# Altium schematic sheet 16-DPLL.SchDoc(16-DPLL)
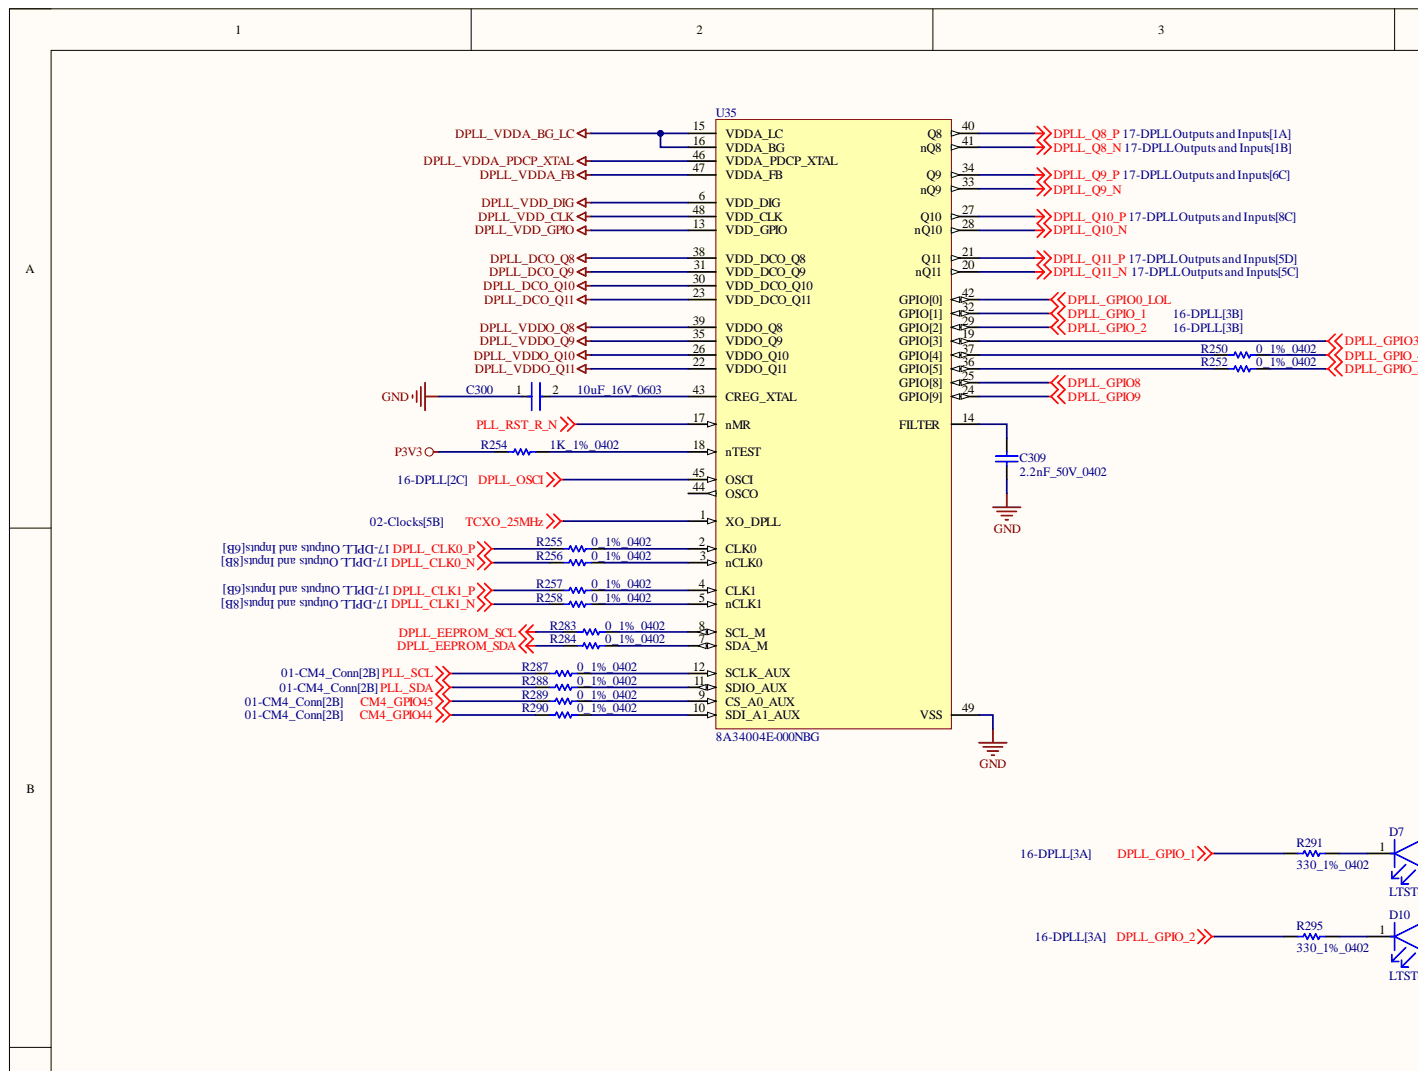
[diagram p0: 16-DPLL.SchDoc, top left]
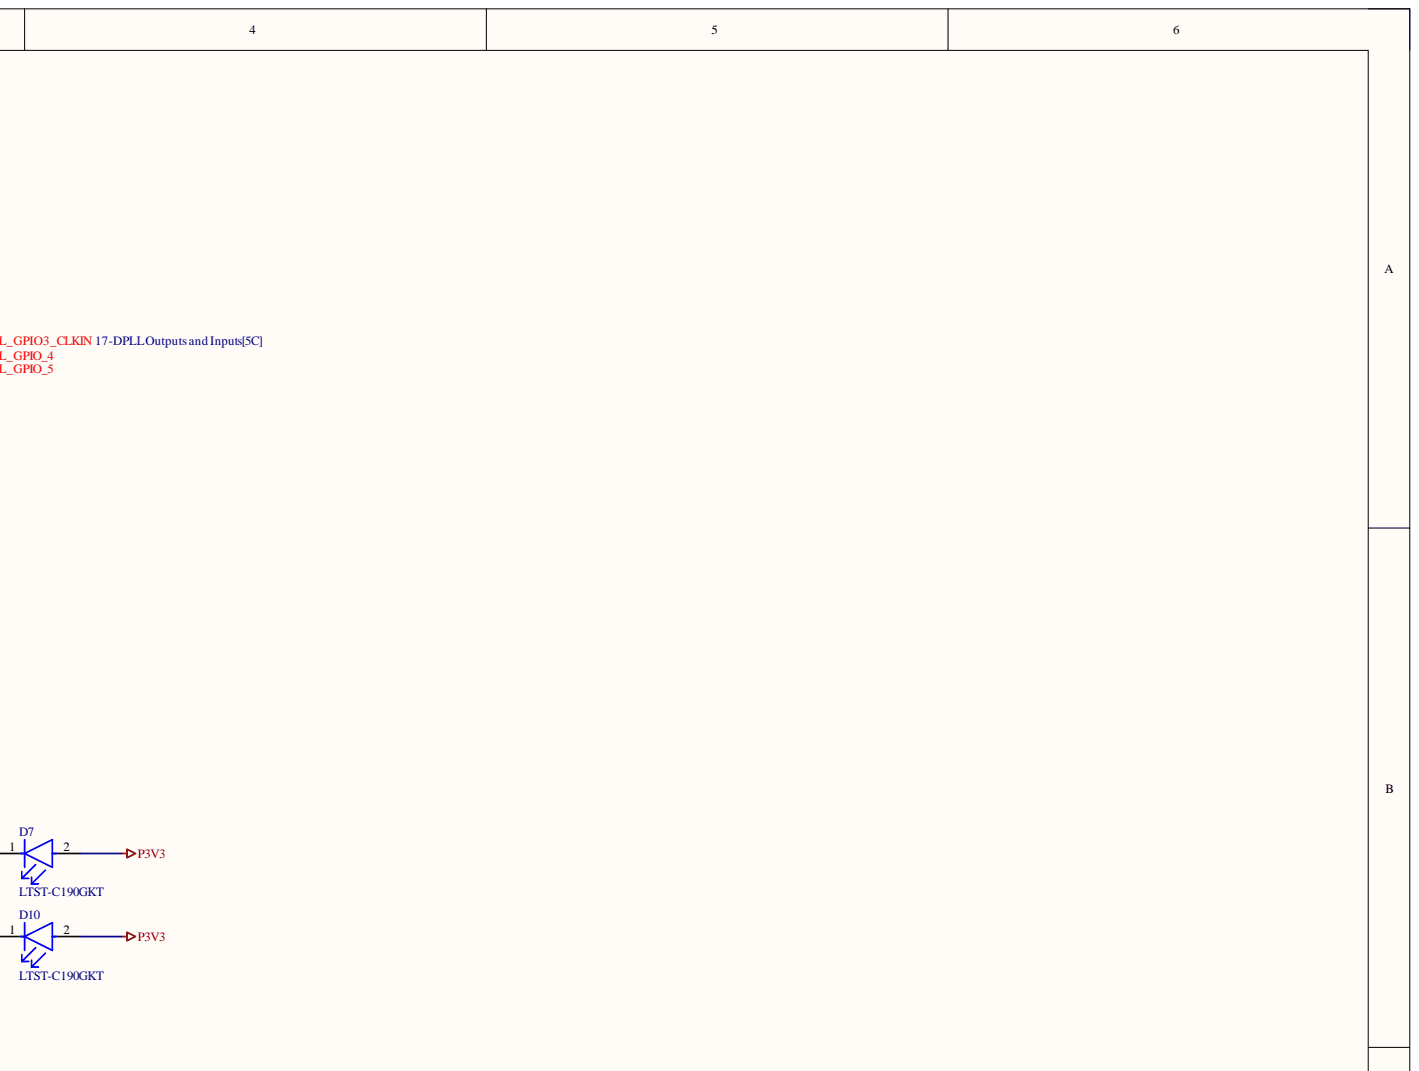
[diagram p1: 16-DPLL.SchDoc, top right]
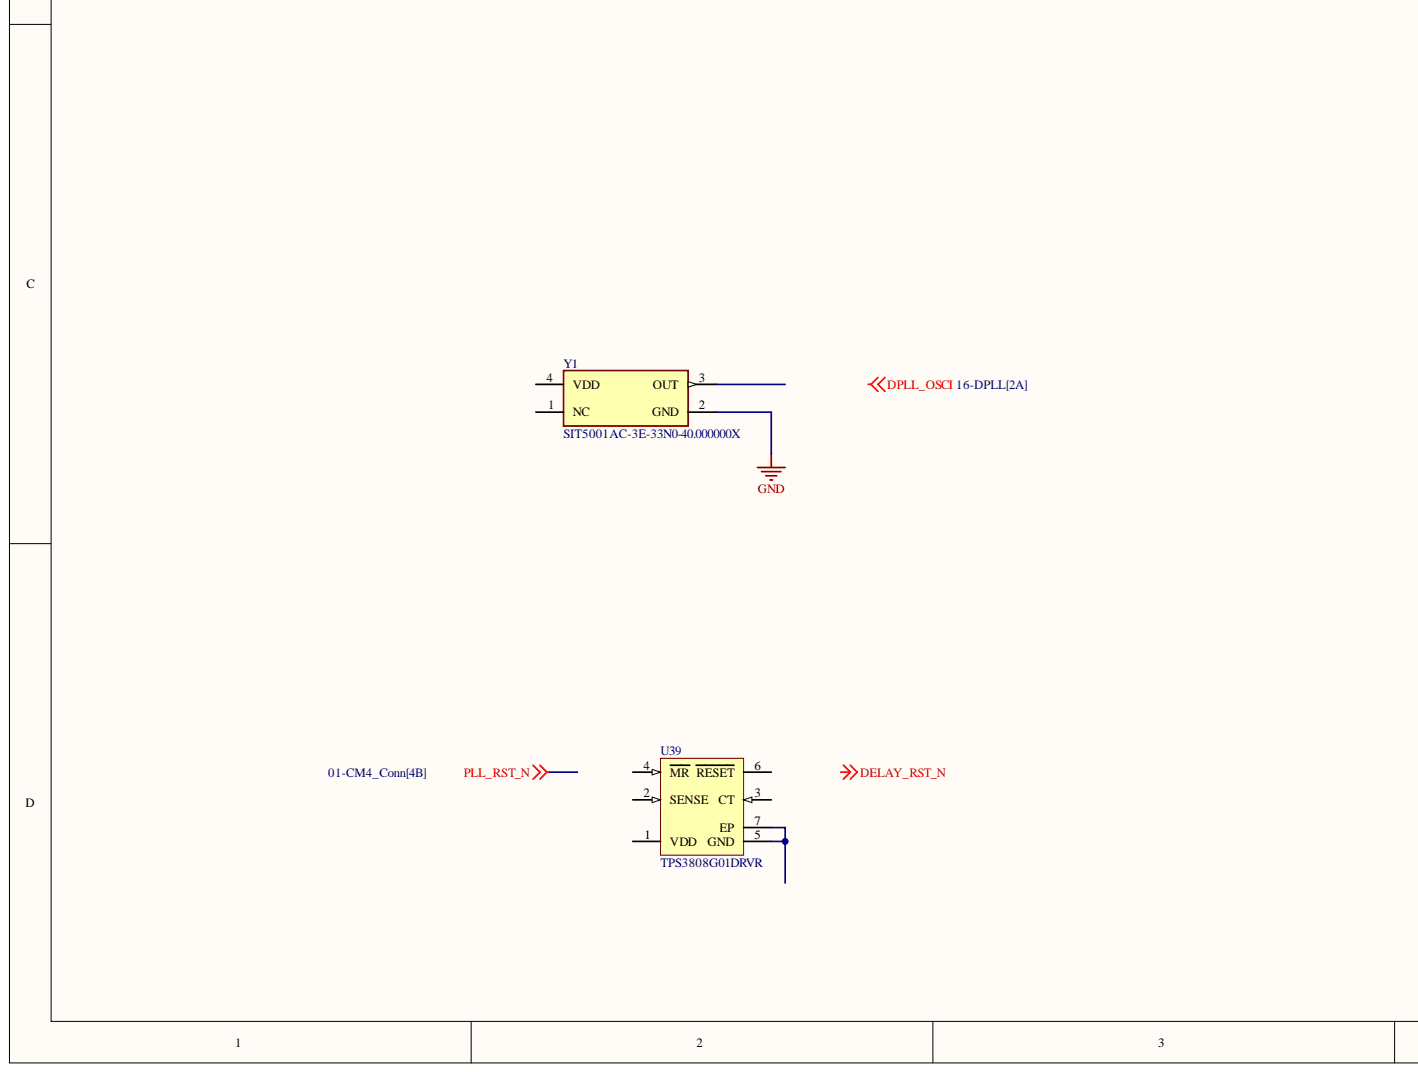
[diagram p2: 16-DPLL.SchDoc, bottom left]
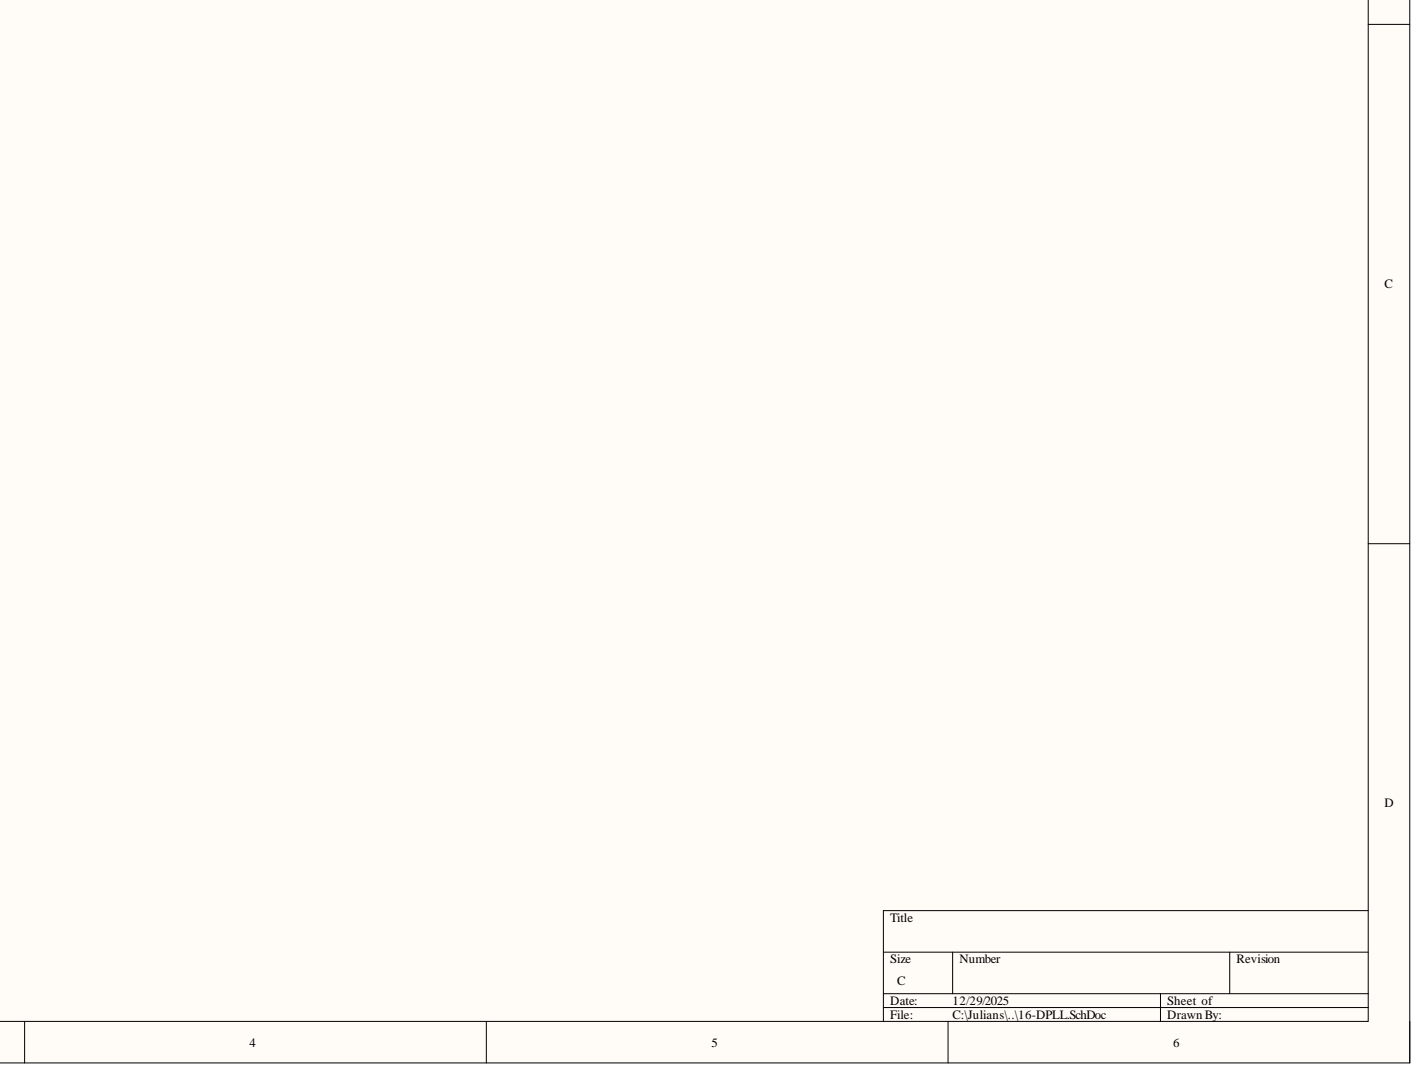
[diagram p3: 16-DPLL.SchDoc, bottom right]

## Parts
C300  10uF_16V_0603  [FP-CC0603-DC-MFG]
C309  2.2nF_50V_0402  [CAPC1005X60X26LL10T16]
D7  LTST-C190GKT  [FP-LTST-C190GKT-MFG]
D10  LTST-C190GKT  [FP-LTST-C190GKT-MFG]
R250  0_1%_0402  [RESC1005X40X25LL05T05]
R252  0_1%_0402  [RESC1005X40X25LL05T05]
R254  1K_1%_0402  [RESC1005X40X25ML05T10]
R255  0_1%_0402  [RESC1005X40X25LL05T05]
R256  0_1%_0402  [RESC1005X40X25LL05T05]
R257  0_1%_0402  [RESC1005X40X25LL05T05]
R258  0_1%_0402  [RESC1005X40X25LL05T05]
R283  0_1%_0402  [RESC1005X40X25LL05T05]
R284  0_1%_0402  [RESC1005X40X25LL05T05]
R287  0_1%_0402  [RESC1005X40X25LL05T05]
R288  0_1%_0402  [RESC1005X40X25LL05T05]
R289  0_1%_0402  [RESC1005X40X25LL05T05]
R290  0_1%_0402  [RESC1005X40X25LL05T05]
R291  330_1%_0402  [RESC1005X40X25NL10T10]
R295  330_1%_0402  [RESC1005X40X25NL10T10]
U35  8A34004E-000NBG  [NBG48P1_MFG]
U39  TPS3808G01DRVR  [DRV0006A_V]
Y1  SIT5001AC-3E-33N0-40.000000X  [FP-SiT5001-3-MFG]

## Nets
CM4_GPIO44: R290.1
CM4_GPIO45: R289.1
DPLL_CLK0_N: R256.1
DPLL_CLK0_P: R255.1
DPLL_CLK1_N: R258.1
DPLL_CLK1_P: R257.1
DPLL_DCO_Q8: U35.38(VDD_DCO_Q8)
DPLL_DCO_Q9: U35.31(VDD_DCO_Q9)
DPLL_DCO_Q10: U35.30(VDD_DCO_Q10)
DPLL_DCO_Q11: U35.23(VDD_DCO_Q11)
DPLL_EEPROM_SCL: R283.1
DPLL_EEPROM_SDA: R284.1
DPLL_GPIO0_LOL: U35.42(GPIO[0])
DPLL_GPIO3_CLKIN: U35.19(GPIO[3])
DPLL_GPIO8: U35.25(GPIO[8])
DPLL_GPIO9: U35.24(GPIO[9])
DPLL_GPIO_1: R291.1 U35.32(GPIO[1])
DPLL_GPIO_2: R295.1 U35.29(GPIO[2])
DPLL_GPIO_4: R250.2
DPLL_GPIO_5: R252.2
DPLL_OSCI: U35.45(OSCI)
DPLL_Q8_N: U35.41(nQ8)
DPLL_Q8_P: U35.40(Q8)
DPLL_Q9_N: U35.33(nQ9)
DPLL_Q9_P: U35.34(Q9)
DPLL_Q10_N: U35.28(nQ10)
DPLL_Q10_P: U35.27(Q10)
DPLL_Q11_N: U35.20(nQ11)
DPLL_Q11_P: U35.21(Q11)
DPLL_VDD_CLK: U35.48(VDD_CLK)
DPLL_VDD_DIG: U35.6(VDD_DIG)
DPLL_VDD_GPIO: U35.13(VDD_GPIO)
DPLL_VDDA_BG_LC: U35.15(VDDA_LC) U35.16(VDDA_BG)
DPLL_VDDA_FB: U35.47(VDDA_FB)
DPLL_VDDA_PDCP_XTAL: U35.46(VDDA_PDCP_XTAL)
DPLL_VDDO_Q8: U35.39(VDDO_Q8)
DPLL_VDDO_Q9: U35.35(VDDO_Q9)
DPLL_VDDO_Q10: U35.26(VDDO_Q10)
DPLL_VDDO_Q11: U35.22(VDDO_Q11)
GND: C300.1 C309.1 U35.49(VSS) Y1.2(GND)
P3V3: D7.2 D10.2 R254.2
PLL_RST_R_N: U35.17(nMR)
PLL_SCL: R287.1
PLL_SDA: R288.1
TCXO_25MHz: U35.1(XO_DPLL)
NetC300_2: C300.2 U35.43(CREG_XTAL)
NetC309_2: C309.2 U35.14(FILTER)
NetD7_1: D7.1 R291.2
NetD10_1: D10.1 R295.2
NetR250_1: R250.1 U35.37(GPIO[4])
NetR252_1: R252.1 U35.36(GPIO[5])
NetR254_1: R254.1 U35.18(nTEST)
NetR255_2: R255.2 U35.2(CLK0)
NetR256_2: R256.2 U35.3(nCLK0)
NetR257_2: R257.2 U35.4(CLK1)
NetR258_2: R258.2 U35.5(nCLK1)
NetR283_2: R283.2 U35.8(SCL_M)
NetR284_2: R284.2 U35.7(SDA_M)
NetR287_2: R287.2 U35.12(SCLK_AUX)
NetR288_2: R288.2 U35.11(SDIO_AUX)
NetR289_2: R289.2 U35.9(CS_A0_AUX)
NetR290_2: R290.2 U35.10(SDI_A1_AUX)
NetU39_5: U39.5(GND) U39.7(EP)
DELAY_RST_N:
PLL_RST_N:

Not connected: U35 44(OSCO); U39 1(VDD) 2(SENSE) 3(CT) 4(MR) 6(RESET); Y1 1(NC) 3(OUT) 4(VDD)

## Library data of the parts
C300 = CMP-00621-81932632-1: CC0603KRX5R7BB106 Multilayer Ceramic Capacitor, 10 uF, 16 V, ± 10%, X5R, 0603 [1608 Metric]; Capacitance: 10µF; Voltage Rating: 16V; Case/Package: 0603; Component Kind: Standard; Dielectric: X5R; Halogen Free: Halogen Free; Height: 1mm; Lead Free: Lead Free; Library Name: Altium Content Vault; Material: Ceramic; Max Operating Temperature: 85°C; Min Operating Temperature: -55°C; NexarPartId: 81932632; RoHS: Non-Compliant; Tolerance: 10%; Voltage Rating (DC): 16V
C309 = CMP-2008-01093-1: Manufacturer: Vitramon; Part Number: VJ0402Y222KXAAP; Component Kind: Standard; Library Name: Altium Content Vault
D7 D10 = CMP-22017-000005-2: LED GREEN CLEAR CHIP SMD; Dominant Wavelength: 569nm; Forward Current: 30mA; Forward Voltage: 2.1V; Lens Color: Colorless; Luminous Intensity: 6mcd; Number of Elements: 1; Power Dissipation: 100mW; Reverse Voltage: 5V; Test Current: 10mA; Viewing Angle: 130°; Voltage: 2V; Wavelength: 565nm; Case Code (Imperial): 0603; Case Code (Metric): 1608; Case/Package: 0603; Color: Green; Component Kind: Standard; Depth: 0.8mm; Height: 0.8mm; Illumination Color: Green; Lead Free: Lead Free; Length: 1.6mm; Lens Style: Clear; Lens Transparency: Clear; Library Name: Altium Content Vault; Max Operating Temperature: 85°C; Min Operating Temperature: -55°C; Mount: Surface Mount; Number of LEDs: 1; Number of Pins: 2; Package Quantity: 1; Packaging: Tape and Reel; Power Rating: 100mW; Radiation Hardening: No; Width: 0.8mm
R250 R252 R255-R258 R283 R284 R287-R290 = CMP-2000-07451-1: Chip Resistor, 0 Ohm, 0.1 W, -55 to 155 degC, 0402 (1005 Metric), RoHS, Tape and Reel; Manufacturer: Panasonic; Max Power Dissipation: 100mW; Resistance: 0mOhm; Temperature Coefficient: 600ppm/��C; Tolerance: 5%; Voltage Rating: 50V; Case Code (Imperial): 0402; Case Code (Metric): 1005; Case/Package: 0402; Component Kind: Standard; Composition: ThickFilm; Contact Plating: Tin; Datasheet URL: https://industrial.panasonic.com/cdbs/www-data/pdf/RDA0000/AOA0000C301.pdf; Datasheet Version: 03/2015; Depth: 0.5mm; Height: 0.35mm; Lead Free: LeadFree; Length: 1mm; Library Name: Altium Content Vault; Manufacturer URL: http://www.panasonic.com/; Max Operating Temperature: 155degC; Military Standard: Not; Min Operating Temperature: -55degC; Mount: SurfaceMount; Mounting Technology: SurfaceMount; Number of Terminations: 2; Package Description: 2-Pin Surface Mount Device, Body 1 x 0.5 mm; Package Quantity: 1; Package Reference: 402; Packaging: TapeandReel; Power Rating: 100mW; Radiation Hardening: No; REACH SVHC: NoSVHC; RoHSCompliant: True; Termination: SMD/SMT; Voltage Rating (DC): 50V; Width: 0.02inch
R254 = CMP-2002-06478-1: Manufacturer: Multicomp; Part Number: MCMR04X1001FTL; Component Kind: Standard; Library Name: Altium Content Vault
R291 R295 = CMP-2002-05853-1: Manufacturer: Panasonic; Part Number: ERA-2AED331X; Component Kind: Standard; Library Name: Altium Content Vault
U35 = CMP-00572-107342130-2: Frequency Synthesiser, -40 To 85Deg C Rohs Compliant: Yes; Manufacturer Lifecycle Status: ACTIVE; Interface: I2C, SPI; Max Input Voltage: 3.465V; Max Output Frequency: 1GHz; Min Input Voltage: 1.71V; Output Type: CML, HCSL, LVCMOS, LVDS, LVPECL; Pitch: 500µm; Component Kind: Standard; ComponentLink1Description: Manufacturer's Website; ComponentLink1URL: http://www.renesas.com; ComponentLink2Description: Datasheet URL; ComponentLink2URL: https://octopart.com/cad-content/api/get-model-datasheet/15189/107342130; ComponentLink3Description: Manufacturer's Package Drawing; ComponentLink3URL: https://www.renesas.com/en/document/psc/package-outline-drawing-package-code-nbg48p1-48-vfqfpn-70-x-70-x-075mm-body-05mm-pitch; Export Control Classification Number (ECCN) Code: NLR; Harmonized Tariff Schedule (HTS) Code: 8540000000; Height: 800µm; HelpURL: https://octopart.com/cad-content/api/get-model-datasheet/15189/107342130; Length: 7mm; Library Name: Altium Content Vault; Lifecycle Status: Production; Max Operating Temperature: 85°C; Min Operating Temperature: -40°C; Mount: Surface Mount; MSL: 3; Number of Pins: 48; Package Quantity: 0; Part Family: 8A34004; RoHS: Compliant; Width: 7mm
U39 = CMP-0332-00391-2: Low Quiescent Current, Programmable-Delay Supervisory Circuit, 1 Supply Monitored, -40 to 125 degC, 6-pin SON (DRV), Green (RoHS & no Sb/Br); Manufacturer: Texas Instruments; # of Supplies Monitored: 1; Iq(Typ)(uA): 2.4; Output Driver Type / Reset Output: Active-low, Open-drain; Rating: Catalog; SubFamily: Supervisor and Reset IC; Threshold Voltage 1 (typ)(Typ)(V): Adjustable; VCC(Max)(V): 6.5; VCC(Min)(V): 1.7; Component Kind: Standard; ComponentLink1Description: Datasheet; ComponentLink1URL: http://www.ti.com/general/docs/lit/getliterature.tsp?genericPartNumber=TPS3808G01&fileType=pdf; DatasheetVersion: SBVS050J; Library Name: Altium Content Vault; Mounting Technology: Surface Mount; PackageDescription: 6-Pin Plastic Small Outline No-Lead, 2 x 2 x 0.8 mm Body, 0.65 mm Pitch, Exposed Pad; PackageReference: DRV0006A; PackageVersion: revE, Oct-2010; PartNumber: TPS3808G01DRVR; Reset Threshold Accuracy(%): 1; Time Delay(ms): Programmable
Y1 = CMP-25159-259584-1: MEMS OSC TCXO 40.0000MHZ LVCMOS; Frequency: 40MHz; Frequency Stability: 5ppm; Max Supply Current: 33mA; Case/Package: SMD/SMT; Component Kind: Standard; Height: 0.031inch; Length: 0.197inch; Library Name: Altium Content Vault; Max Operating Temperature: 70°C; Min Operating Temperature: -20°C; Mount: Surface Mount; Packaging: Cut Tape; Series: SiT5001; Width: 0.126inch
